# Altium schematic sheet lv_power_5V_cv.SchDoc(lv_power_5V_cv)
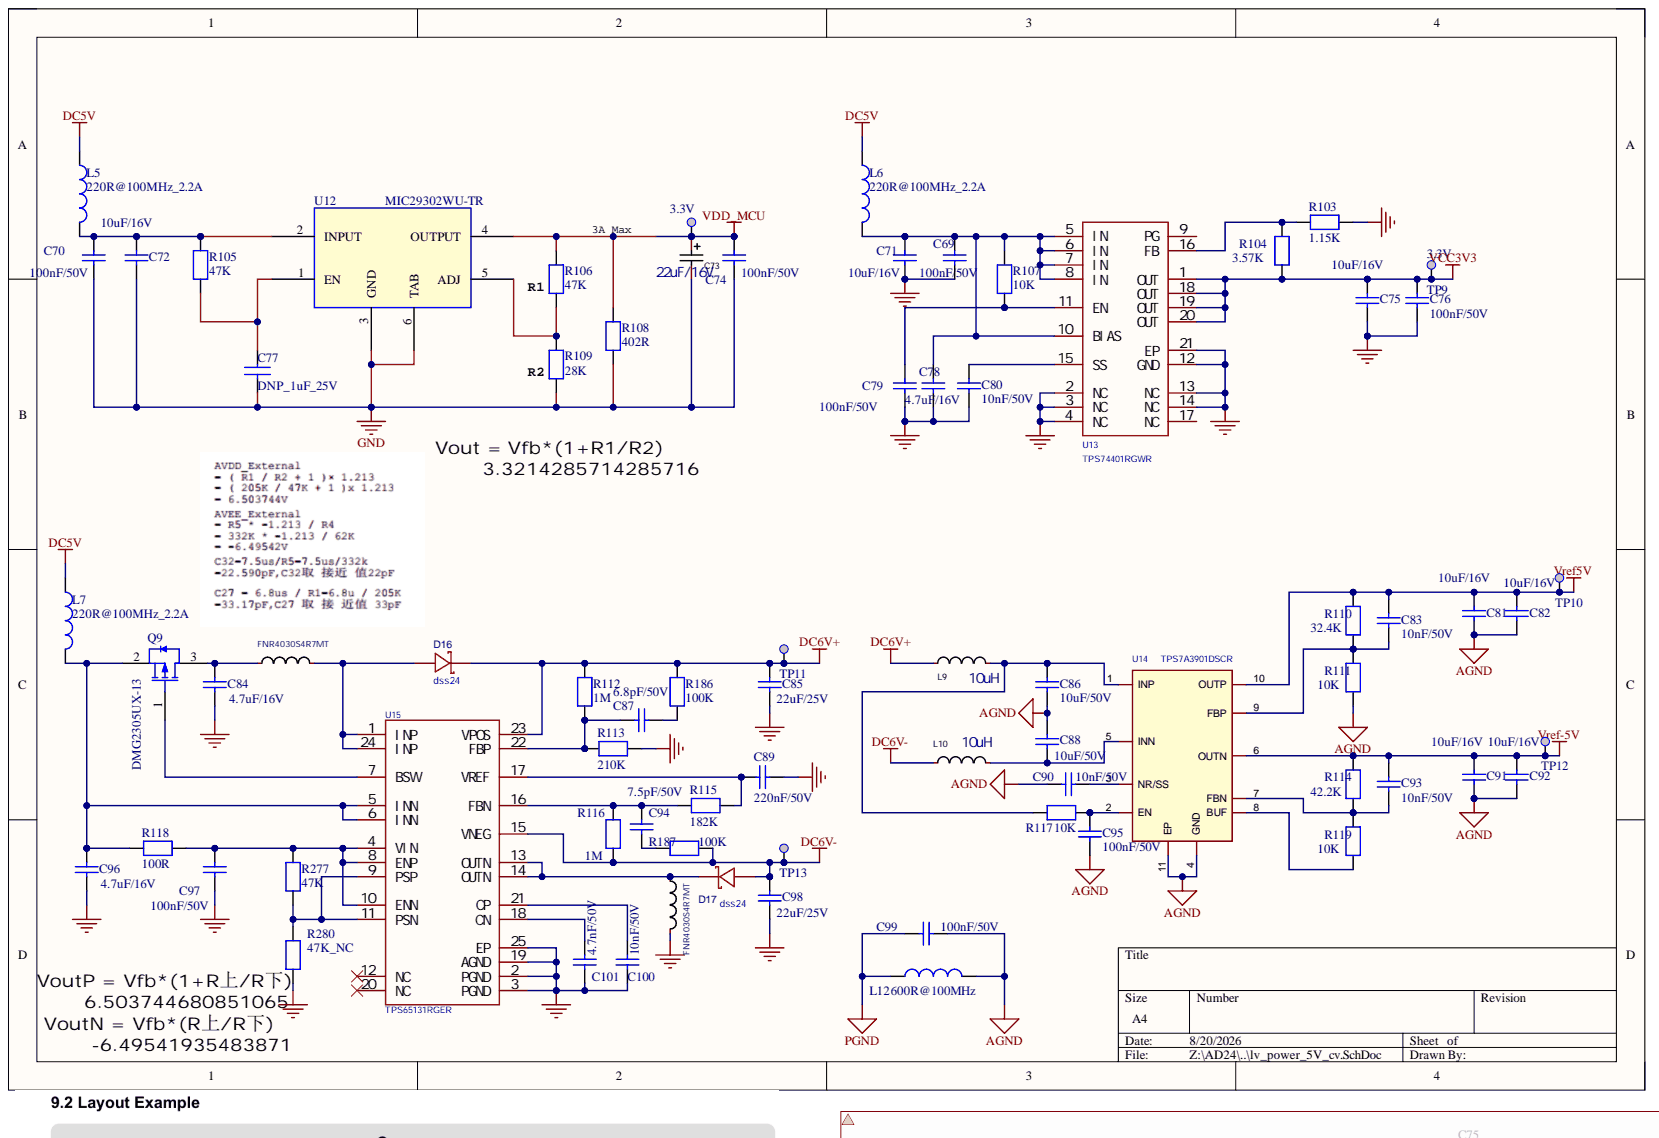
[diagram p0: lv_power_5V_cv.SchDoc]

## Parts
C69  100nF/50V  [C0402]
C70  100nF/50V  [C0402]
C71  10uF/16V  [C0805]
C72  10uF/16V  [C0805]
C73  22uF/16V  [CAP-SMD_L6.0-W3.2-R-RD]
C74  100nF/50V  [C0402]
C75  10uF/16V  [C0805]
C76  100nF/50V  [C0402]
C77  DNP_1uF_25V  [C0603]
C78  4.7uF/16V  [C0603]
C79  100nF/50V  [C0402]
C80  10nF/50V  [C0402]
C81  10uF/16V  [C0805]
C82  10uF/16V  [C0805]
C83  10nF/50V  [C0402]
C84  4.7uF/16V  [C0603]
C85  22uF/25V  [C0805]
C86  10uF/50V  [C1206]
C87  6.8pF/50V  [C0402]
C88  10uF/50V  [C1206]
C89  220nF/50V  [C0402]
C90  10nF/50V  [C0402]
C91  10uF/16V  [C0805]
C92  10uF/16V  [C0805]
C93  10nF/50V  [C0402]
C94  7.5pF/50V  [C0402]
C95  100nF/50V  [C0402]
C96  4.7uF/16V  [C0603]
C97  100nF/50V  [C0402]
C98  22uF/25V  [C0805]
C99  100nF/50V  [C0402]
C100  10nF/50V  [C0402]
C101  4.7nF/50V  [C0402]
D16  dss24  [SOD-123_L2.8-W1.8-LS3.7-RD]
D17  dss24  [SOD-123_L2.8-W1.8-LS3.7-RD]
L5  220R@100MHz_2.2A  [L0603]
L6  220R@100MHz_2.2A  [L0603]
L7  220R@100MHz_2.2A  [L0603]
L8  FNR4030S4R7MT  [IND-SMD_L4.0-W4.0]
L9  10uH  [R0805]
L10  10uH  [R0805]
L11  FNR4030S4R7MT  [IND-SMD_L4.0-W4.0]
L12  600R@100MHz  [L0603]
Q9  DMG2305UX-13  [SOT-23(SOT-23-3)]
R103  1.15K  [R0402]
R104  3.57K  [R0402]
R105  47K  [R0402]
R106  47K  [R0402]
R107  10K  [R0402]
R108  402R  [0805]
R109  28K  [R0402]
R110  32.4K  [R0603]
R111  10K  [R0603]
R112  1M  [R0402]
R113  210K  [R0402]
R114  42.2K  [R0603]
R115  182K  [R0402]
R116  1M  [R0402]
R117  10K  [R0603]  (value not drawn)
R118  100R  [R0603]
R119  10K  [R0603]
R186  100K  [R0402]
R187  100K  [R0402]
R277  47K  [R0402]
R280  47K_NC  [R0402]
TP8  3.3V  [TP_SMD_1MM]  (no BOM)
TP9  3.3V  [TP_SMD_1MM]  (no BOM)
TP10  +5.0V  [TP_SMD_1MM]  (value not drawn)  (no BOM)
TP11  +6V  [TP_SMD_1MM]  (value not drawn)  (no BOM)
TP12  -5.0V  [TP_SMD_1MM]  (value not drawn)  (no BOM)
TP13  -6V  [TP_SMD_1MM]  (value not drawn)  (no BOM)
U12  MIC29302WU-TR  [TO-263-5_L10.2-W8.6-P1.70-LS14.4-TL]
U13  TPS74401RGWR  [VQFN-20_L5.0-W5.0-P0.65-TL-EP]
U14  TPS7A3901DSCR  [WSON-10_L3.0-W3.0-P0.50-BL-EP]
U15  TPS65131RGER  [VQFN-24_L4.0-W4.0-P0.50-TL-EP]

## Nets
AGND: C81.1 C82.1 C86.2 C88.2 C90.1 C91.1 C92.1 C95.2 C99.2 L12.2 R111.1 U14.4(GND) U14.11(EP)
DC5V: L5.2 L6.2 L7.2
DC6V+: C85.2 D16.1 L9.1 R112.2 R186.2 TP11.1 U15.23(VPOS)
DC6V-: C98.2 D17.2 L10.1 R116.2 R187.2 TP13.1 U15.15(VNEG)
GND: C69.2 C70.2 C71.1 C72.1 C73.2 C74.2 C75.1 C76.2 C77.2 C78.1 C79.2 C80.2 C84.2 C85.1 C89.1 C96.2 C97.2 C98.1 C100.2 C101.2 L11.2 R103.1 R108.2 R109.2 R113.2 R280.1 U12.3(GND) U12.6(TAB) U13.2(NC) U13.3(NC) U13.4(NC) U13.12(GND) U13.13(NC) U13.14(NC) U13.21(EP) U15.2(PGND) U15.3(PGND) U15.19(AGND) U15.25(EP)
PGND: C99.1 L12.1
VCC3V3: C75.2 C76.1 R104.1 TP9.1 U13.1(OUT) U13.18(OUT) U13.19(OUT) U13.20(OUT)
VDD_MCU: C73.1 C74.1 R106.1 R108.1 TP8.1 U12.4(OUTPUT)
Vref5V: C81.2 C82.2 C83.1 R110.1 TP10.1 U14.10(OUTP)
Vref-5V: C91.2 C92.2 C93.1 R114.1 TP12.1 U14.6(OUTN)
NetC69_1: C69.1 C71.2 C78.2 L6.1 R107.1 U13.5(IN) U13.6(IN) U13.7(IN) U13.8(IN) U13.10(BIAS)
NetC70_1: C70.1 C72.2 L5.1 R105.1 U12.2(INPUT)
NetC77_1: C77.1 R105.2 U12.1(EN)
NetC79_1: C79.1 R107.2 U13.11(EN)
NetC80_1: C80.1 U13.15(SS)
NetC83_2: C83.2 R110.2 R111.2 U14.9(FBP)
NetC84_1: C84.1 L8.1 Q9.3
NetC86_1: C86.1 L9.2 R117.1 U14.1(INP)
NetC87_1: C87.1 R112.1 R113.1 U15.22(FBP)
NetC87_2: C87.2 R186.1
NetC88_1: C88.1 L10.2 U14.5(INN)
NetC89_2: C89.2 R115.1 U15.17(VREF)
NetC90_2: C90.2 U14.3(NR/SS)
NetC93_2: C93.2 R114.2 R119.2 U14.7(FBN)
NetC94_1: C94.1 R115.2 R116.1 U15.16(FBN)
NetC94_2: C94.2 R187.1
NetC95_1: C95.1 R117.2 U14.2(EN)
NetC96_1: C96.1 L7.1 Q9.2 R118.1 U15.5(INN) U15.6(INN)
NetC97_1: C97.1 R118.2 R277.2 U15.4(VIN) U15.8(ENP) U15.10(ENN)
NetC100_1: C100.1 U15.21(CP)
NetC101_1: C101.1 U15.18(CN)
NetD16_2: D16.2 L8.2 U15.1(INP) U15.24(INP)
NetD17_1: D17.1 L11.1 U15.13(OUTN) U15.14(OUTN)
NetQ9_1: Q9.1 U15.7(BSW)
NetR103_2: R103.2 R104.2 U13.16(FB)
NetR106_2: R106.2 R109.1 U12.5(ADJ)
NetR119_1: R119.1 U14.8(BUF)
NetR277_1: R277.1 R280.2 U15.9(PSP) U15.11(PSN)

Not connected: U13 9(PG) 17(NC); U15 12(NC) 20(NC)

## Library data of the parts
C69 C70 C74 C76 C79 C95 C97 C99 = Capacitor_2: 50V, X7R , 10%; Manufacturer: muRata; Manufacturer_Part_Number: GRM155R71H104KE14D; Component Kind: Standard; ComponentLink1Description: Datasheet; ComponentLink1URL: http://tunmcdsvn.tun.st.com/Component_Datasheet_Library/Trunk/Capacitors/Yageo_Multilayer_capacitor_X5R_series_100pF_to_100uF; Library Name: HiPin_Library_AD.IntLib
C71 C72 C75 C81 C82 C91 C92 = Capacitor_3: 16V, X7R, 10%; Manufacturer: YAGEO(国巨); Manufacturer_Part_Number: CC0805KKX7R7BB106; Component Kind: Standard; Library Name: HiPin_Library_AD.IntLib
C73 = TAJD107M020RNJ: 16V，±10%，1Ω@100kHz; Manufacturer: Kyocera AVX; Manufacturer Part: TAJC226K016RNJ; Component Kind: Standard; Library Name: HiPin_Library_AD.IntLib
C77 = CAP NP: DNP_1uF_25V_10%; Manufacturer Character: 中国大陆; As-Welded: DNP; Operation Temperature（℃）: -40~85℃; Component Kind: Standard; PCB Footprint: C0603
C78 = MCD-01121: 16V, X7S, 10%,; Manufacturer: MURATA; Manufacturer_Part_Number: GRM188R61C475KE11D; Database Table Name: MCD_PARTS$; Component Kind: Standard; ComponentLink1Description: Datasheet; ComponentLink1URL: http://tunmcdsvn.tun.st.com/Component_Datasheet_Library/Trunk/Capacitors/Murata_Capacitor_GR_series; Library Name: MCD_PARTS_database.DbLib
C80 C83 C90 C93 = Capacitor_2: 50V, X7R , 5%; Manufacturer: YAGEO; Manufacturer_Part_Number: CC0402JRX7R9BB103; Component Kind: Standard; ComponentLink1Description: Datasheet; ComponentLink1URL: http://tunmcdsvn.tun.st.com/Component_Datasheet_Library/Trunk/Capacitors/Yageo_Multilayer_capacitor_X5R_series_100pF_to_100uF; Library Name: HiPin_Library_AD.IntLib
C84 = Capacitor_2: 16V, X7S , 10%; Manufacturer: muRata(村田); Manufacturer_Part_Number: GRM188R61E475KE11D; Component Kind: Standard; Library Name: HiPin_Library_AD.IntLib
C85 C98 = Capacitor_2: 25V, X5R, 10%; Internal_Part_Number: MCD-00014; Manufacturer: muRata; Manufacturer_Part_Number: GRM21BR61E226ME44L; Component Kind: Standard; ComponentLink1Description: Datasheet; ComponentLink1URL: http://tunmcdsvn.tun.st.com/Component_Datasheet_Library/Trunk/Capacitors/Yageo_Multilayer_capacitor_X5R_series_100pF_to_100uF; Library Name: HiPin_Library_AD.IntLib
C86 = MCD-00097: 50V, X5R, 10%; Alternate_Manufacturer: Murata; Alternate_Manufacturer_Part_Number: GRM188R61C475MAAJ; Manufacturer: YAGEO(国巨); Manufacturer_Part_Number: CC0805KKX7R7BB106; Database Table Name: MCD_PARTS$; ECCN_EU: NEC; ECCN_US: EAR99; Provided_By: SUBCO; Component Kind: Standard; ComponentLink1Description: Datasheet; ComponentLink1URL: http://tunmcdsvn.tun.st.com/Component_Datasheet_Library/Trunk/Capacitors/Murata_Capacitor_GR_series; Library Name: MCD_PARTS_database.DbLib; Package: C0603; Status: Released
C87 = Capacitor_2: 50V, X7R , 10%; Manufacturer: muRata; Manufacturer_Part_Number: GJM1555C1H6R8BB01D; Component Kind: Standard; ComponentLink1Description: Datasheet; ComponentLink1URL: http://tunmcdsvn.tun.st.com/Component_Datasheet_Library/Trunk/Capacitors/Yageo_Multilayer_capacitor_X5R_series_100pF_to_100uF; Library Name: HiPin_Library_AD.IntLib
C88 = MCD-00097: 50V, X5R, 10%; Database Table Name: MCD_PARTS$; Component Kind: Standard; ComponentLink1Description: Datasheet; ComponentLink1URL: http://tunmcdsvn.tun.st.com/Component_Datasheet_Library/Trunk/Capacitors/Murata_Capacitor_GR_series; Library Name: MCD_PARTS_database.DbLib
C89 = Capacitor_2: 50V, X5R , 10%; Manufacturer: muRata; Manufacturer_Part_Number: GRM155R61H224KE01D; Component Kind: Standard; ComponentLink1Description: Datasheet; ComponentLink1URL: http://tunmcdsvn.tun.st.com/Component_Datasheet_Library/Trunk/Capacitors/Yageo_Multilayer_capacitor_X5R_series_100pF_to_100uF; Library Name: HiPin_Library_AD.IntLib
C94 = Capacitor_2: 50V, X7R , 10%; Manufacturer: muRata; Manufacturer_Part_Number: GRM1555C1H7R5BA01D; Component Kind: Standard; ComponentLink1Description: Datasheet; ComponentLink1URL: http://tunmcdsvn.tun.st.com/Component_Datasheet_Library/Trunk/Capacitors/Yageo_Multilayer_capacitor_X5R_series_100pF_to_100uF; Library Name: HiPin_Library_AD.IntLib
C96 = Capacitor_2: 16V, X7S, 10%; Manufacturer: muRata(村田); Manufacturer_Part_Number: GRM188R61E475KE11D; Component Kind: Standard; Library Name: HiPin_Library_AD.IntLib
C100 = Capacitor_2: 50V, X7R , 10%; Manufacturer: muRata; Manufacturer_Part_Number: GRM155R71H103KA88D; Component Kind: Standard; ComponentLink1Description: Datasheet; ComponentLink1URL: http://tunmcdsvn.tun.st.com/Component_Datasheet_Library/Trunk/Capacitors/Yageo_Multilayer_capacitor_X5R_series_100pF_to_100uF; Library Name: HiPin_Library_AD.IntLib
C101 = Capacitor_2: 50V, X7R , 10%; Manufacturer: muRata; Manufacturer_Part_Number: GRM1555C1H472JE01D; Component Kind: Standard; ComponentLink1Description: Datasheet; ComponentLink1URL: http://tunmcdsvn.tun.st.com/Component_Datasheet_Library/Trunk/Capacitors/Yageo_Multilayer_capacitor_X5R_series_100pF_to_100uF; Library Name: HiPin_Library_AD.IntLib
D16 D17 = dss24: 正向压降(Vf):550mV@2A;直流反向耐压(Vr):40V;整流电流:2A;; Manufacturer: BORN(伯恩半导体); AddInBom: yes; Current - Rectified: 2A; ModelId: 7884390a25fb4c08947731ebfba7e3f8; ModelTransform: 144.8816,62.992,0,0,0,0,0,0,0; Operating Junction Temperature Range: -65℃~+150℃; Reverse Leakage Current (Ir): 500uA@40V; Symbol: DSS24_C511865; Voltage - DC Reverse(Vr): 40V; Component Kind: Standard; ConvertToPcb: yes; Datasheet: https://item.szlcsc.com/datasheet/DSS24/526330.html; FootprintName: SOD-123_L2.8-W1.8-LS3.7-RD; JLCPCB Part Class: Extended Part; LCSC Part Name: 电压:40V 电流:2A; ManufacturerPart: DSS24; ModelName: SOD-123_L2.8-W1.8-LS3.7-RD; Supplier: LCSC; Supplier Footprint: SOD-123FL; SupplierPart: C511865; Voltage - Forward(Vf@If): 550mV@2A
  D16: Library Name: HiPin_Library_AD.IntLib
L5-L7 = MCD-00047: SMD Chip ferrite bead, 220R@100MHz +/-25%,2.2A, 50mohm; Manufacturer: Sunlord; Manufacturer_Part_Number: UPZ1608U221-2R2TF; Database Table Name: MCD_PARTS$; Component Kind: Standard; ComponentLink1Description: Datasheet; ComponentLink1URL: http://tunmcdsvn.tun.st.com/Component_Datasheet_Library/Trunk/Inductors/TaiTech_Bead_FCM1608_series; Internal_Part_Number: MCD-00047; Library Name: MCD_PARTS_database.DbLib
L8 L11 = LQH44PN1R0NP0L: 4.7uH ±20% 2.3A  DCR 78mΩ; Manufacturer: muRata(村田); Component Kind: Standard; Library Name: HiPin_Library_AD.IntLib; Manufacturer Part: FNR4030S4R7MT; PCB3D: IND-SMD_L4.0-W4.0-H1.9
L9 L10 = WPN252012H1R0MT: ±20% ,0.36A, 550mΩ; Manufacturer Part: SLM2012100MIT; Component Kind: Standard; Library Name: HiPin_Library_AD.IntLib
L12 = MCD-00047: +/-25%, 350mA, 500mohm DC; Manufacturer: TAI-TECH; Manufacturer_Part_Number: FCM1608KF-601T03; Database Table Name: MCD_PARTS$; Component Kind: Standard; ComponentLink1Description: Datasheet; ComponentLink1URL: http://tunmcdsvn.tun.st.com/Component_Datasheet_Library/Trunk/Inductors/TaiTech_Bead_FCM1608_series; Library Name: MCD_PARTS_database.DbLib
Q9 = AO3401A P道沟 X13A15 SOT-23: P沟道,-20V,-5A,40mΩ@-4.5V; Manufactu: DIODES(美台); Component Kind: Standard; Library Name: HiPin_Library_AD.IntLib; ManufacturerNumber: DMG2305UX-13; SuppliersPartNumber: C8549
R103-R119 R186 R187 R277 R280 = RES: 1%; Component Kind: Standard; Library Name: HiPin_Library_AD.IntLib
TP8-TP13 = MCD-00074: Test Point 1mm; Part_Type: Mechanical; Database Table Name: MCD_PARTS$; ComponentLink1Description: Datasheet; ComponentLink1URL: http://na; ECCN_EU: n/a; ECCN_US: n/a; Internal_Part_Number: MCD-00074; Library Name: MCD_PARTS_database.DbLib; Manufacturer: n/a; Manufacturer_Part_Number: n/a; Provided_By: n/a; Status: Released
U12 = MIC29302WU: LDO,Vin:26V,Iout:3A; Manufacturer: 广东华冠半导体有限公司（HGSEMI）; Manufacturer Character: 中国大陆; Manufacturer PartNumber: MIC29302S/TR; Operation Temperature（℃）: -40~125℃; PCB Footprint: TO-263; Component Kind: Standard; Temperature: -60~150℃
U13 = TPS74401RGWR: LDO; Manufacturer: TI(德州仪器); Add into BOM: yes; Dropout Voltage: 240mV@(3A); Maximum Input Voltage: 5.5V; Number of Outputs: 1; Output Current: 3A; Output Polarity: 正极; Output Type: 可调; Output Voltage: 800mV~3.6V; Power Supply Rejection Ratio (PSRR): 73dB; Component Kind: Standard; Convert to PCB: yes; Datasheet: https://www.ti.com/cn/lit/gpn/tps74401; Device: TPS74401RGWR; Feature: 防静电保护；浪涌保护；热保护(TSD)；欠压闭锁；过流保护(OCP)；带使能; JLCPCB Part Class: Extended Part; LCSC Part Name: 3A、低输入电压 (0.8V)、低噪声、高 PSRR、可调节超低压降稳压器; Library Name: HiPin_Library_AD.IntLib; Manufacturer Part: TPS74401RGWR; PCB3D: VQFN-20_L5.0-W5.0-H1.0-P0.65; Supplier: LCSC; Supplier Footprint: VQFN-20-EP(5x5); Supplier Part: C138728; Symbol: TPS74401RGWR
U14 = TPS7A3901DSCR: 双电源LDO; Manufacturer: TI(德州仪器); Add into BOM: yes; Maximum Input Voltage: 33V; Number of Outputs: 2; Output Current: 150mA; Output Polarity: 正极和负极; Output Type: 可调; Output Voltage: 1.2V~30V；0V~30V; Power Supply Rejection Ratio (PSRR): 56dB@(100KHz); Component Kind: Standard; Convert to PCB: yes; Datasheet: https://www.ti.com/cn/lit/gpn/tps7a39; Device: TPS7A3901DSCR; JLCPCB Part Class: Extended Part; LCSC Part Name: 150mA、33V、低噪声、高 PSRR、双通道、正负电压范围、低压降稳压器; Library Name: HiPin_Library_AD.IntLib; Manufacturer Part: TPS7A3901DSCR; Operating Temperature: -40℃~+125℃@(Tj); PCB3D: WSON-10_L3.0-W3.0-H1.0-P0.50; Supplier: LCSC; Supplier Footprint: WSON-10-EP(3x3); Supplier Part: C2685819; Symbol: TPS7A3901DSCR
U15 = TPS65130RGER: 双通道正负输出300mA双电源转换器; Manufacturer: TI(德州仪器); Add into BOM: yes; Frequency - Switching: 1.38MHz; Number of Outputs: 2; Output Type: 可调; Output Voltage: ±15V; Switch tube (built-in/external): 内置; Synchronous Rectifier: 否; Topology: 升压式；反相式; Voltage - Supply: 2.7V~5.5V; Component Kind: Standard; Convert to PCB: yes; Datasheet: https://www.ti.com/cn/lit/gpn/tps65130; Function: -; JLCPCB Part Class: Extended Part; Library Name: HiPin_Library_AD.IntLib; Manufacturer Part: TPS65131RGER; Operating Temperature: -40℃~+85℃@(TA); Output Current: -; PCB3D: VQFN-24_L4.0-W4.0-H1.0-P0.50; Quiescent Current: -; Supplier: LCSC; Supplier Footprint: VQFN-24-EP(4x4); Supplier Part: C54989
